# Altium schematic sheet Config MCU Schematic.SchDoc("Config MCU Schematic")
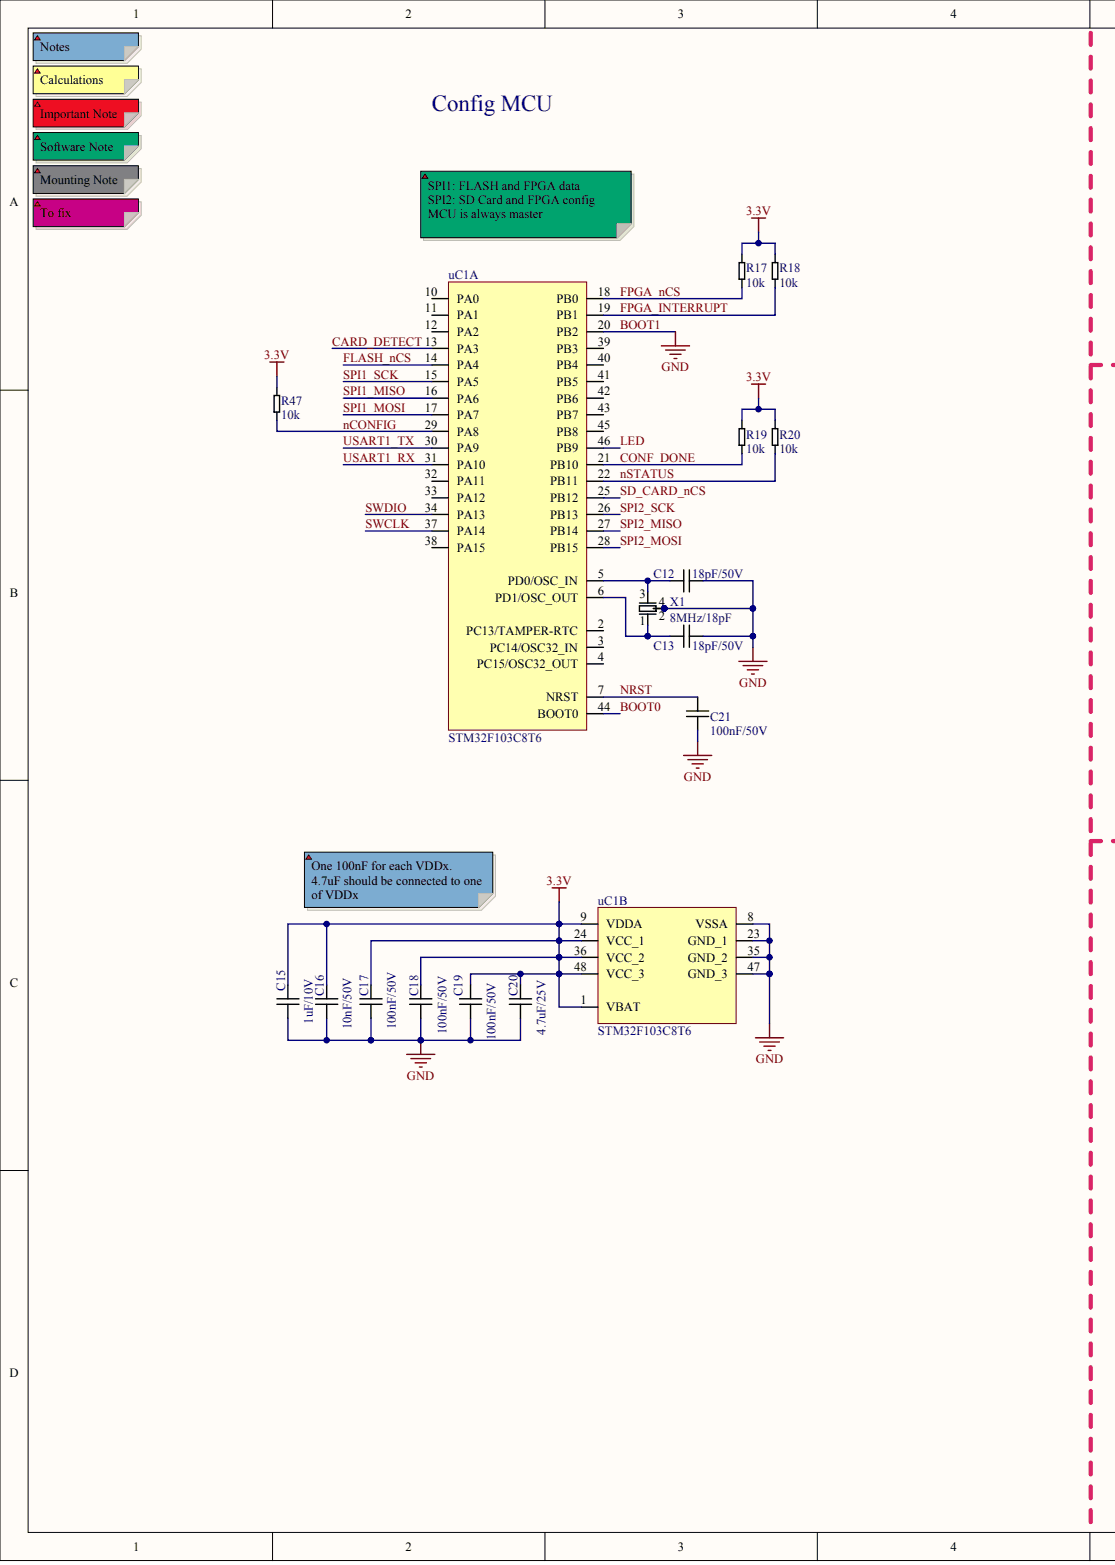
[diagram p0: Config MCU Schematic.SchDoc, left]
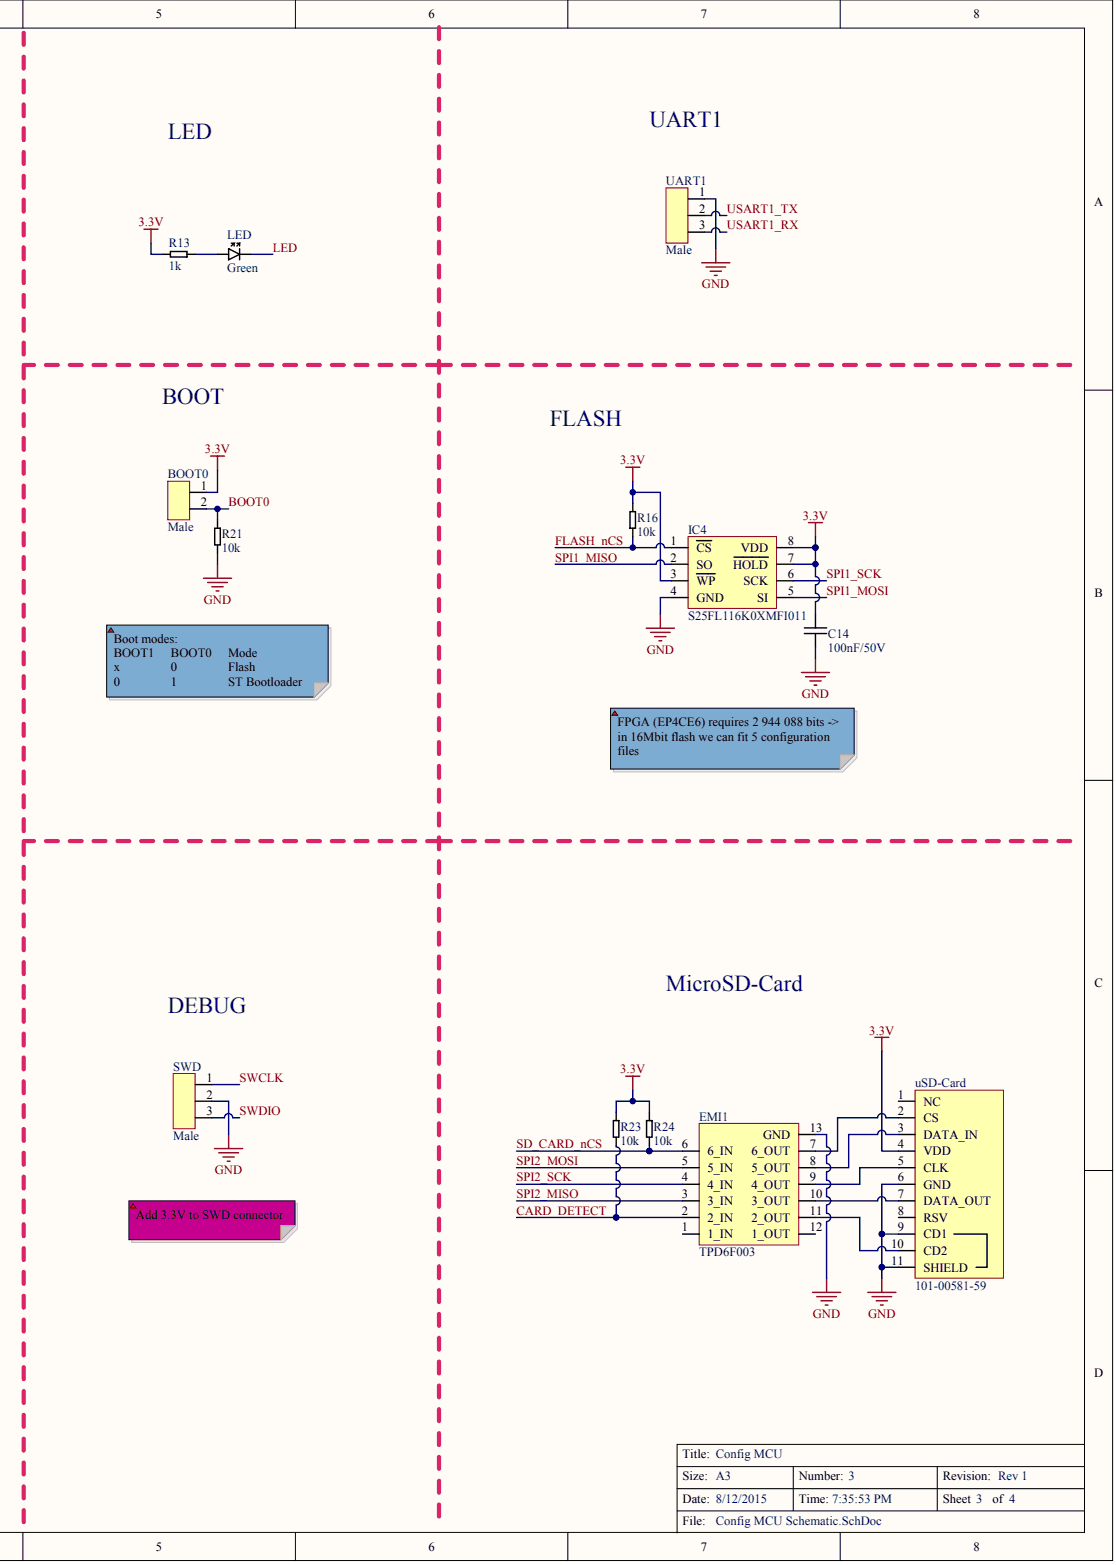
[diagram p1: Config MCU Schematic.SchDoc, right]

## Parts
BOOT0  value Male  (hidden comment: Connector 1x2)
C12  value 18pF/50V  [0603]  (hidden comment: Cap_0603_18pF/50V)
C13  value 18pF/50V  [0603]  (hidden comment: Cap_0603_18pF/50V)
C14  value 100nF/50V  [0603]  (hidden comment: Cap_0603_100nF/50V)
C15  value 1uF/10V  [0603]  (hidden comment: Cap_0603_1uF/10V)
C16  value 10nF/50V  [0603]  (hidden comment: Cap_0603_10nF/50V)
C17  value 100nF/50V  [0603]  (hidden comment: Cap_0603_100nF/50V)
C18  value 100nF/50V  [0603]  (hidden comment: Cap_0603_100nF/50V)
C19  value 100nF/50V  [0603]  (hidden comment: Cap_0603_100nF/50V)
C20  value 4.7uF/25V  [0805]  (hidden comment: Cap_0805_4.7uF/25V)
C21  value 100nF/50V  [0603]  (hidden comment: Cap_0603_100nF/50V)
EMI1  value TPD6F003  [WSON-12]  (hidden comment: EMI Filter 6ch Pi)
IC4  S25FL116K0XMFI011
LED  value Green  (hidden comment: LED_0603_Green)
R13  value 1k  [0603]  (hidden comment: Res_0603_1k)
R16  value 10k  [0603]  (hidden comment: Res_0603_10k)
R17  value 10k  [0603]  (hidden comment: Res_0603_10k)
R18  value 10k  [0603]  (hidden comment: Res_0603_10k)
R19  value 10k  [0603]  (hidden comment: Res_0603_10k)
R20  value 10k  [0603]  (hidden comment: Res_0603_10k)
R21  value 10k  [0603]  (hidden comment: Res_0603_10k)
R23  value 10k  [0603]  (hidden comment: Res_0603_10k)
R24  value 10k  [0603]  (hidden comment: Res_0603_10k)
R47  value 10k  [0603]  (hidden comment: Res_0603_10k)
SWD  value Male  (hidden comment: Connector 1x3)
UART1  value Male  (hidden comment: Connector 1x3)
uC1  STM32F103C8T6  [LQFP-48]  (parts A,B)
uSD-Card  value 101-00581-59  Part No.: 101-00581-59  (hidden comment: microSD Card Holder SMD)
X1  value 8MHz/18pF  [4-pin SMD 5x3mm]  (hidden comment: 7B-8.000MAAJ-T)

## Nets
3.3V: BOOT0.1 C14.2 C15.2 C16.2 C17.2 C18.2 C19.2 C20.2 IC4.3(WP) IC4.7(HOLD) IC4.8(VDD) R13.1 R16.1 R17.1 R18.1 R19.1 R20.1 R23.2 R24.2 R47.1 uC1.1(VBAT) uC1.9(VDDA) uC1.24(VCC_1) uC1.36(VCC_2) uC1.48(VCC_3) uSD-Card.4(VDD)
BOOT0: BOOT0.2 R21.2 uC1.44(BOOT0)
CARD_DETECT: EMI1.2(2_IN) R23.1 uC1.13(PA3)
CONF_DONE: R19.2 uC1.21(PB10)
FLASH_NCS: IC4.1(CS) R16.2 uC1.14(PA4)
FPGA_INTERRUPT: R18.2 uC1.19(PB1)
FPGA_NCS: R17.2 uC1.18(PB0)
GND: C12.2 C13.2 C14.1 C15.1 C16.1 C17.1 C18.1 C19.1 C20.1 C21.2 EMI1.13(GND) IC4.4(GND) R21.1 SWD.2 UART1.1 uC1.8(VSSA) uC1.20(PB2) uC1.23(GND_1) uC1.35(GND_2) uC1.47(GND_3) uSD-Card.6(GND) uSD-Card.9(CD1) uSD-Card.11(SHIELD) X1.2 X1.4
LED: LED.CATHODE uC1.46(PB9)
NCONFIG: R47.2 uC1.29(PA8)
NRST: C21.1 uC1.7(NRST)
NSTATUS: R20.2 uC1.22(PB11)
SD_CARD_NCS: EMI1.6(6_IN) R24.1 uC1.25(PB12)
SPI1_MISO: IC4.2(SO) uC1.16(PA6)
SPI1_MOSI: IC4.5(SI) uC1.17(PA7)
SPI1_SCK: IC4.6(SCK) uC1.15(PA5)
SPI2_MISO: EMI1.3(3_IN) uC1.27(PB14)
SPI2_MOSI: EMI1.5(5_IN) uC1.28(PB15)
SPI2_SCK: EMI1.4(4_IN) uC1.26(PB13)
SWCLK: SWD.1 uC1.37(PA14)
SWDIO: SWD.3 uC1.34(PA13)
USART1_RX: UART1.3 uC1.31(PA10)
USART1_TX: UART1.2 uC1.30(PA9)
NetC12_1: C12.1 uC1.5(PD0/OSC_IN) X1.3
NetC13_1: C13.1 uC1.6(PD1/OSC_OUT) X1.1
NetEMI1_7: EMI1.7(6_OUT) uSD-Card.2(CS)
NetEMI1_8: EMI1.8(5_OUT) uSD-Card.3(DATA_IN)
NetEMI1_9: EMI1.9(4_OUT) uSD-Card.5(CLK)
NetEMI1_10: EMI1.10(3_OUT) uSD-Card.7(DATA_OUT)
NetEMI1_11: EMI1.11(2_OUT) uSD-Card.10(CD2)
NetLED_ANODE: LED.ANODE R13.2

Not connected: EMI1 1(1_IN) 12(1_OUT); uC1 2(PC13/TAMPER-RTC) 3(PC14/OSC32_IN) 4(PC15/OSC32_OUT) 10(PA0) 11(PA1) 12(PA2) 32(PA11) 33(PA12) 38(PA15) 39(PB3) 40(PB4) 41(PB5) 42(PB6) 43(PB7) 45(PB8); uSD-Card 1(NC) 8(RSV)

## Library data of the parts
C12 C13 = (no symbol name): Part No.: C1608C0G1H180J080AA; Manufacturer: TDK; RS: 788-2944 (50-pack); Price SEK (No VAT): 0,3; DigiKey: 445-1272-1-ND; Mouser: 810-C1608C0G1H180J; Farnell: 1907287
C14 C17-C19 C21 = (no symbol name): Part No.: C1608X7R1H104K080AA; Manufacturer: TDK; RS: 741-4459 (500-pack); DigiKey: 445-1314-1-ND; Mouser: 810-C1608X7R1H104K; Price SEK (No VAT): 0,2
  C18: Value: 100nF/50V
C15 = (no symbol name): Part No.: C1608X5R1A105K080AC; Manufacturer: TDK; Mouser: 810-C1608X5R1A105K; Price SEK (No VAT): 1; DigiKey: 445-1321-1-ND; Value: 1uF/10V
C16 = (no symbol name): Part No.: C1608X7R1H103K080AA; Manufacturer: TDK; RS: 788-2981 (50-pack); DigiKey: 445-1311-1-ND; Mouser: 810-C1608X7R1H103K; Price SEK (No VAT): 0,3; Farnell: 1907315 (50-pack)
C20 = (no symbol name): Part No.: GRM21BR61E475KA12L; Manufacturer: Murata; DigiKey: 490-3335-1-ND; Mouser: 81-GRM21BR61E475KA12; Price SEK (No VAT): 1
EMI1 = (no symbol name): Part No.: TPD6F003DQDR; Manufacturer: TI; RS: 812-0225 (25-pack); Price SEK (No VAT): 7; DigiKey: 296-25802-1-ND; Mouser: 595-TPD6F003DQDR; Farnell: 1863883
IC4 = (no symbol name): Manufacturer: Spansion; DigiKey: 1274-1105-ND; Part No.: S25FL116K0XMFI011; Datasheet: http://www.spansion.com/Support/Datasheets/S25FL1-K_00.pdf; Mouser: 797-25FL116KOXMFI011; Price SEK (No VAT): 5; Farnell: 2363337; Value: S25FL116K0XMFI011
LED = (no symbol name): Part No.: APT1608CGCK; Manufacturer: Kingbright; Mouser: 604-APT1608CGCK; Price SEK (No VAT): 1; DigiKey: 754-1116-1-ND
R13 = (no symbol name): Part No.: CRCW06031K00FKEA; Manufacturer: Vishay; Price SEK (No VAT): 0,1; DigiKey: 541-1.00KHCT-ND; Mouser: 71-CRCW0603-1.0K-E3
R16-R21 R23 R24 R47 = (no symbol name): Part No.: CRCW060310K0FKEA; Manufacturer: Vishay; Price SEK (No VAT): 0,1; Mouser: 71-CRCW0603-10K-E3; DigiKey: 541-10.0KHCT-ND
uC1 = (no symbol name): Manufacturer: ST; Part No.: STM32F103C8T6; Mouser: 511-STM32F103C8T6; DigiKey: 497-6063-ND; Price SEK (No VAT): 47; Value: STM32F103C8T6
uSD-Card = (no symbol name): Manufacturer: Amphenol; Mouser: 523-101-00581-59; DigiKey: 101-00581-59-1-ND; Price SEK (No VAT): 15; Datasheet: https://www.amphenolcanada.com/ProductSearch/drawings/AC/1010058159.pdf
X1 = (no symbol name): Manufacturer: TXC; Value: 8MHz/18pF; Part No.: 7B-8.000MAAJ-T; Mouser: 717-7B-8.000MAAJ-T; DigiKey: 887-1452-1-ND; Price SEK (No VAT): 8
